# Altium schematic sheet Audio_Output_Stereo_Out.SchDoc
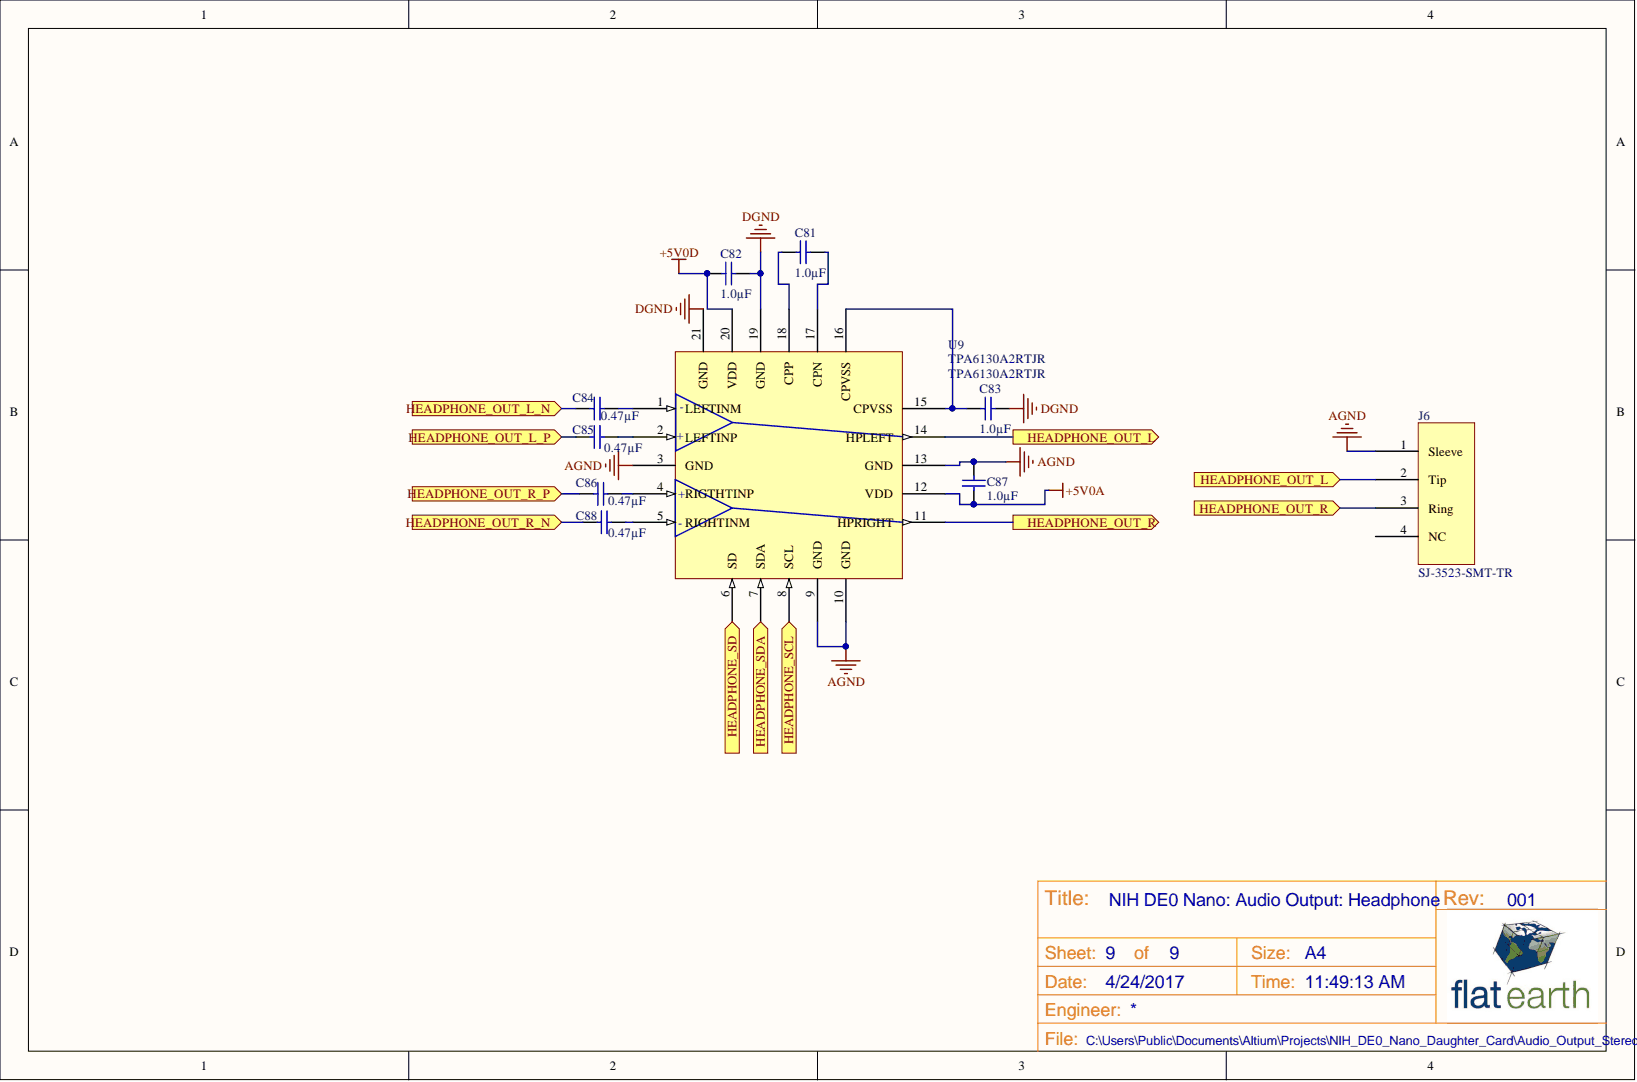
[diagram p0: Audio_Output_Stereo_Out.SchDoc]

## Parts
C81  Capacitance: 1.0µF  [3-0603_L]  (hidden comment: C1608X7R1C105K)
C82  Capacitance: 1.0µF  [3-0603_L]  (hidden comment: C1608X7R1C105K)
C83  Capacitance: 1.0µF  [3-0603_L]  (hidden comment: C1608X7R1C105K)
C84  Capacitance: 0.47µF  [3-0603_L]  (hidden comment: C1608X7R1H474K080AC)
C85  Capacitance: 0.47µF  [3-0603_L]  (hidden comment: C1608X7R1H474K080AC)
C86  Capacitance: 0.47µF  [3-0603_L]  (hidden comment: C1608X7R1H474K080AC)
C87  Capacitance: 1.0µF  [3-0603_L]  (hidden comment: C1608X7R1C105K)
C88  Capacitance: 0.47µF  [3-0603_L]  (hidden comment: C1608X7R1H474K080AC)
J6  SJ-3523-SMT-TR  [SJ-3523-SMT-TR]
U9  TPA6130A2RTJR  [QFN50P400X400X100-20L]

## Ports
HEADPHONE_OUT_L, HEADPHONE_OUT_L_N, HEADPHONE_OUT_L_P, HEADPHONE_OUT_R, HEADPHONE_OUT_R_N, HEADPHONE_OUT_R_P, HEADPHONE_SCL, HEADPHONE_SD, HEADPHONE_SDA

## Nets
+5V0A: C87.2 U9.12(VDD)
+5V0D: C82.1 U9.20(VDD)
AGND: C87.1 J6.1(Sleeve) U9.3(GND) U9.9(GND) U9.10(GND) U9.13(GND)
DGND: C82.2 C83.2 U9.19(GND) U9.21(GND)
HEADPHONE_OUT_L: J6.2(Tip) U9.14(HPLEFT) ; port HEADPHONE_OUT_L
HEADPHONE_OUT_L_N: C84.1 ; port HEADPHONE_OUT_L_N
HEADPHONE_OUT_L_P: C85.1 ; port HEADPHONE_OUT_L_P
HEADPHONE_OUT_R: J6.3(Ring) U9.11(HPRIGHT) ; port HEADPHONE_OUT_R
HEADPHONE_OUT_R_N: C88.1 ; port HEADPHONE_OUT_R_N
HEADPHONE_OUT_R_P: C86.1 ; port HEADPHONE_OUT_R_P
HEADPHONE_SCL: U9.8(SCL) ; port HEADPHONE_SCL
HEADPHONE_SD: U9.6(SD) ; port HEADPHONE_SD
HEADPHONE_SDA: U9.7(SDA) ; port HEADPHONE_SDA
NetC81_1: C81.1 U9.18(CPP)
NetC81_2: C81.2 U9.17(CPN)
NetC83_1: C83.1 U9.15(CPVSS) U9.16(CPVSS)
NetC84_2: C84.2 U9.1(-_LEFTINM)
NetC85_2: C85.2 U9.2(+_LEFTINP)
NetC86_2: C86.2 U9.4(+_RIGTHTINP)
NetC88_2: C88.2 U9.5(-_RIGHTINM)

Not connected: J6 4(NC)

## Library data of the parts
C81-C83 C87 = C1608X7R1C105K: CAP CER 1UF 16V 10% X7R 0603; Manufacturer: TDK Corporation; Applications: General Purpose; Category: Capacitors; Component Kind: Standard; ComponentLink1Description: http://www.tdk.com/pdf/general_B11.pdf; ComponentLink1URL: http://www.tdk.com/pdf/general_B11.pdf; Features: -; Height - Seated (Max): -; Lead Spacing: -; Lead Style: -; Library Name: Schematic_Components.SchLib; Manufacturer Part Number: C1608X7R1C105K; Mounting Type: Surface Mount, MLCC; Operating Temperature: -55°C ~ 125°C; Package / Case: 0603 (1608 Metric); Packaging: Cut Tape (CT); Pricing 1: 10=0.077, 100=0.0366, 250=0.0308, 500=0.0258, 1000=0.02118 (USD); Ratings: -; RoHS: RoHS Compliant; Size / Dimension: 0.063" L x 0.031" W (1.60mm x 0.80mm); Stock 1: 1908993; Supplier 1: Digi-Key; Supplier Part Number 1: 445-1604-1-ND; Temperature Coefficient: X7R; Thickness (Max): 0.037" (0.95mm); Tolerance: ±10%; Voltage - Rated: 16V
C84-C86 C88 = C1608X7R1H474K080AC: CAP CER 0.47UF 50V 10% X7R 0603; Manufacturer: TDK Corporation; Applications: General Purpose; Category: Capacitors; Component Kind: Standard; ComponentLink1Description: http://product.tdk.com/capacitor/mlcc/en/documents/mlcc_commercial_general_en.pdf; ComponentLink1URL: http://product.tdk.com/capacitor/mlcc/en/documents/mlcc_commercial_general_en.pdf; Features: -; Height - Seated (Max): -; Lead Spacing: -; Lead Style: -; Library Name: Schematic_Components.SchLib; Manufacturer Part Number: C1608X7R1H474K080AC; Mounting Type: Surface Mount, MLCC; Operating Temperature: -55°C ~ 125°C; Package / Case: 0603 (1608 Metric); Packaging: Cut Tape (CT); Pricing 1: 1=0.24, 10=0.2, 100=0.1, 250=0.075, 500=0.06626, 1000=0.05875 (USD); Ratings: -; RoHS: RoHS Compliant; Size / Dimension: 0.063" L x 0.031" W (1.60mm x 0.80mm); Stock 1: 0; Supplier 1: Digi-Key; Supplier Part Number 1: 445-5952-1-ND; Temperature Coefficient: X7R; Thickness (Max): 0.037" (0.95mm); Tolerance: ±10%; Voltage - Rated: 50V
J6 = SJ-3523-SMT-TR: 3.50mm (0.141", 1/8", Mini Plug) - Headphone Phone Jack Stereo Connector Solder; Manufacturer: CUI Inc.; Actual Diameter: 0.142" (3.60mm); Body Color: Black; Current Rating: 1A; Gender: Female; Includes: 1 pc - 1 Connector; Internal Switch(s): Does Not Contain Switch; Mating Length/Depth: 0.551" (14.00mm); Number of Positions/Contacts: 3 Conductors, 3 Contacts; Shielding: Unshielded; Voltage - Rated: 12VDC; Body Material: -; Category: Connectors, Interconnects; Component Kind: Standard; ComponentLink1Description: http://www.cui.com/product/resource/digikeypdf/sj-352x-smt_series.pdf; ComponentLink1URL: http://www.cui.com/product/resource/digikeypdf/sj-352x-smt_series.pdf; Connector Type: Phone Jack; Contact Material - Plating: Silver; Features: Board Guide; Industry Recognized Mating Diameter: 3.50mm (0.141", 1/8", Mini Plug) - Headphone; Insulation Color: -; LatestRevisionDate: 17-Jul-2002; LatestRevisionNote: Re-released for DXP Platform.; Manufacturer Part Number: SJ-3523-SMT-TR; Mounting Type: Surface Mount, Right Angle; Packaging: Cut Tape (CT); Part Status: Active; Pricing 1: 1=1.34, 10=1.043, 100=0.7961, 500=0.63558 (USD); RoHS: RoHS Compliant; Signal Lines: Stereo; Stock 1: 104981; Supplier 1: Digi-Key; Supplier Part Number 1: CP-3523SJCT-ND; Termination: Solder; Library Name: NIH_DE0_Nano_Daughter_Card_Library.SchLib; Publisher: Altium Limited
U9 = TPA6130A2RTJR: Amplifier IC Headphones, 2-Channel (Stereo) Class AB 20-QFN (4x4); Manufacturer: Texas Instruments; Max Output Power x Channels @ Load: 290mW x 1 @ 16 Ohm; 138mW x 2 @ 16 Ohm; Voltage - Supply: 2.5 V ~ 5.5 V; Category: Integrated Circuits (ICs); Component Kind: Standard; ComponentLink1Description: http://www.ti.com/lit/ds/symlink/tpa6130a2.pdf; ComponentLink1URL: http://www.ti.com/lit/ds/symlink/tpa6130a2.pdf; Features: Depop, Differential Inputs, I²C, Mute, Short-Circuit and Thermal Protection, Shutdown, Volume Control; LatestRevisionDate: 17-Jul-2002; LatestRevisionNote: Re-released for DXP Platform.; Manufacturer Part Number: TPA6130A2RTJR; Mounting Type: Surface Mount; Operating Temperature: -40°C ~ 85°C (TA); Output Type: Headphones, 2-Channel (Stereo); Package / Case: 20-WFQFN Exposed Pad; Packaging: Cut Tape (CT); Part Status: Active; Pricing 1: 1=2.19, 10=1.966, 100=1.5768, 500=1.296, 1000=0.9 (USD); RoHS: RoHS Compliant; Stock 1: 15763; Supplier 1: Digi-Key; Supplier Device Package: 20-QFN (4x4); Supplier Part Number 1: 296-21656-1-ND; Type: Class AB; Library Name: NIH_DE0_Nano_Daughter_Card_Library.SchLib; Publisher: Altium Limited
